# Altium schematic sheet 00_Power_Module.SchDoc
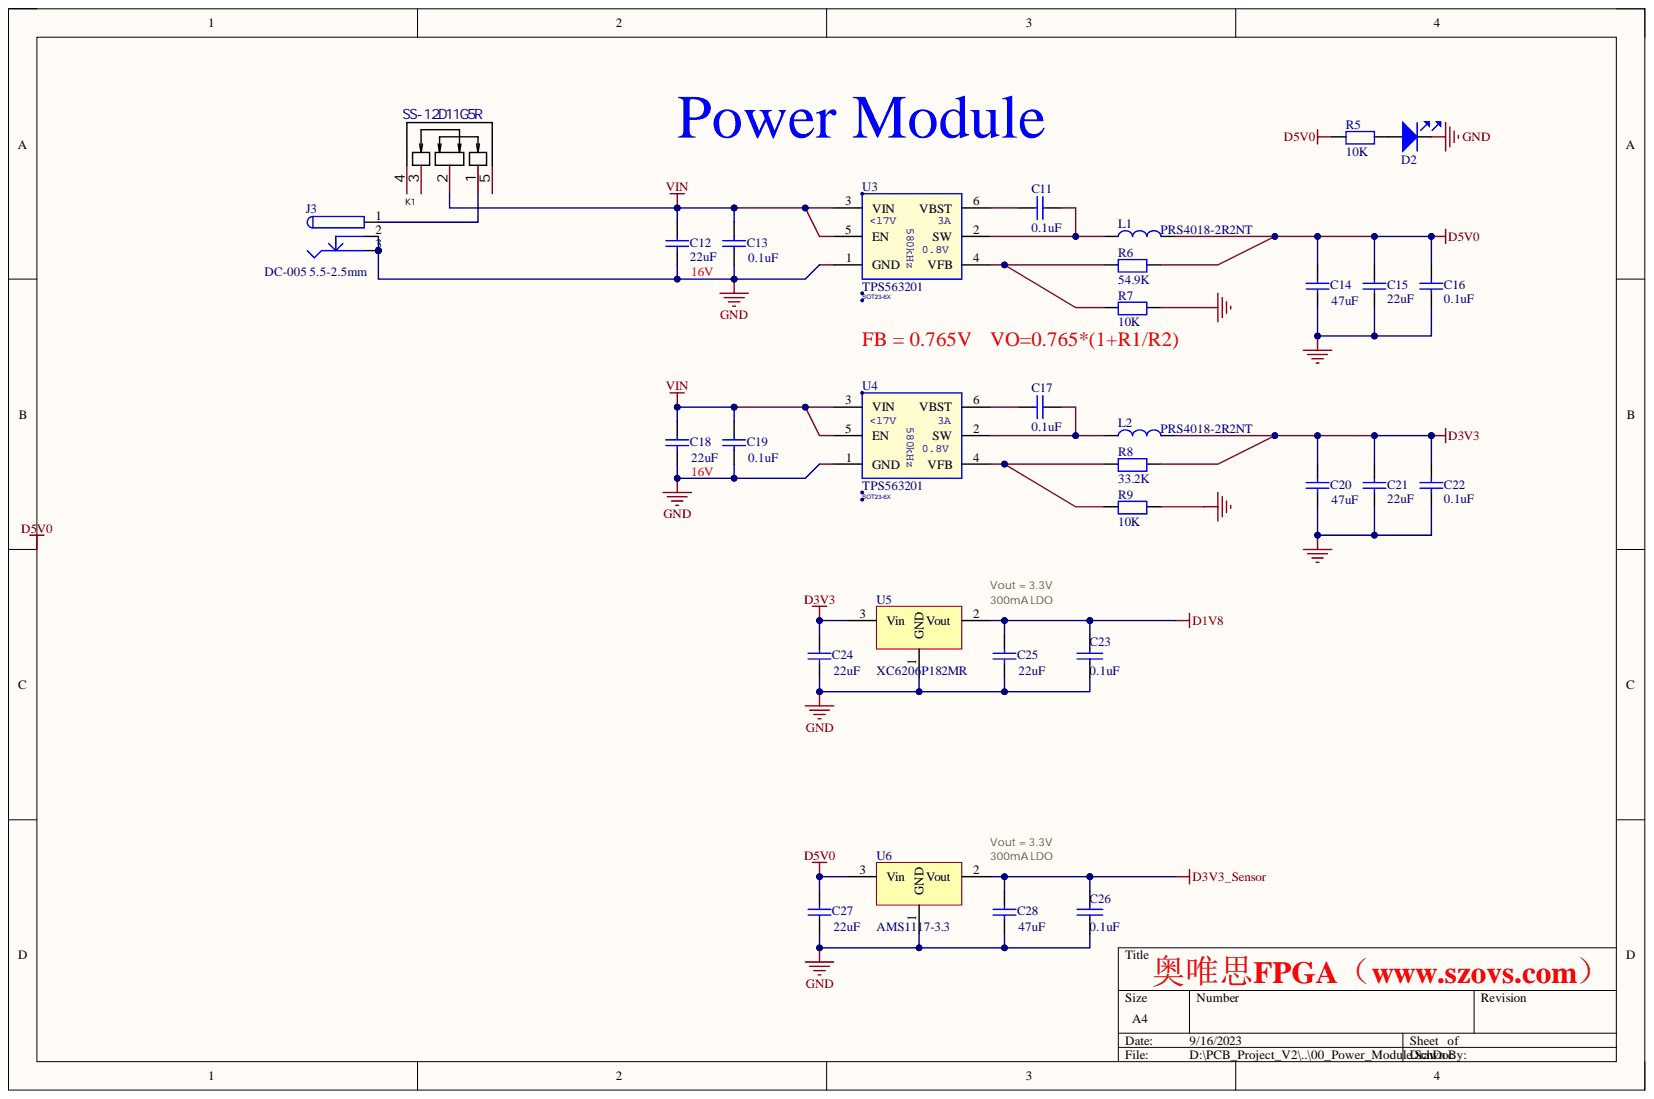
[diagram p0: 00_Power_Module.SchDoc]

## Parts
C11  0.1uF  [R0402]
C12  22uF  [R0805]
C13  0.1uF  [R0402]
C14  47uF  [R1206]
C15  22uF  [R0805]
C16  0.1uF  [R0402]
C17  0.1uF  [R0402]
C18  22uF  [R0805]
C19  0.1uF  [R0402]
C20  47uF  [R1206]
C21  22uF  [R0805]
C22  0.1uF  [R0402]
C23  0.1uF  [R0402]
C24  22uF  [R0805]
C25  22uF  [R0805]
C26  0.1uF  [R0402]
C27  22uF  [R0805]
C28  47uF  [R1206]
D2  LED红  [D0603]  (value not drawn)
J3  DC-005 5.5-2.5mm  [source]
K1  SS-12D11G5R  [SW-TH_SS-12D11G5R]
L1  PRS4018-2R2NT  [PRS4018]
L2  PRS4018-2R2NT  [PRS4018]
R5  10K  [R0402]
R6  54.9K  [R0402]
R7  10K  [R0402]
R8  33.2K  [R0402]
R9  10K  [R0402]
U3  TPS563201  PCB Footprint: SOT23-6X  [SOT23-6]
U4  TPS563201  PCB Footprint: SOT23-6X  [SOT23-6]
U5  XC6206P182MR  [SOT23-3]
U6  AMS1117-3.3  [SOT-89]

## Nets
D1V8: C23.1 C25.2 U5.2
D3V3: C20.2 C21.2 C22.2 C24.2 L2.2 R8.2 U5.3
D3V3_Sensor: C26.1 C28.2 U6.2
D5V0: C14.2 C15.2 C16.2 C27.2 L1.2 R5.1 R6.2 U6.3
GND: C12.1 C13.1 C14.1 C15.1 C16.1 C18.1 C19.1 C20.1 C21.1 C22.1 C23.2 C24.1 C25.1 C26.2 C27.1 C28.1 D2.2 J3.2 J3.3 R7.2 R9.2 U3.1(GND) U4.1(GND) U5.1 U6.1
VIN: C12.2 C13.2 C18.2 C19.2 K1.2 U3.3(VIN) U3.5(EN) U4.3(VIN) U4.5(EN)
NetC11_1: C11.1 L1.1 U3.2(SW)
NetC11_2: C11.2 U3.6(VBST)
NetC17_1: C17.1 L2.1 U4.2(SW)
NetC17_2: C17.2 U4.6(VBST)
NetD2_1: D2.1 R5.2
NetJ3_1: J3.1 K1.1
NetR6_1: R6.1 R7.1 U3.4(VFB)
NetR8_1: R8.1 R9.1 U4.4(VFB)

Not connected: K1 3 4 5

## Library data of the parts
C11-C22 C24 C25 C27 C28 = CAP: Component Kind: Standard; Library Name: Crazy_PCB.SchLib
C23 C26 = CAP: Capacitor; Component Kind: Standard; Library Name: RC522.IntLib
D2 = LED: LED 发光二极管; Component Kind: Standard; Library Name: Crazy_PCB.SchLib
J3 = SOURCE: Component Kind: Standard; LatestRevisionDate: 17-Jul-2002; LatestRevisionNote: Re-released for DXP Platform.; Library Name: Crazy_PCB.SchLib; Publisher: Altium Limited
K1 = SS-12D11G5R: Manufacturer: XKB Connectivity(中国星坤); Actuator Color: 黑色; Actuator Style: 矩形柱状; Add into BOM: yes; Circuit: 单刀双掷; Current Rating (AC): 5A; Mechanical Life: 1万次; Pin Style: PC引脚; Switch Height: 6.3mm; Switch Length: 13.5mm; Switch Width: 6.6mm; Voltage Rating (AC): 125V; Component Kind: Standard; Convert to PCB: yes; Current Rating (DC): 5A; Datasheet: https://atta.szlcsc.com/upload/public/pdf/source/20211009/84F89DEF741E27E9E55DE4039DC07CAD.pdf; Device: SS-12D11G5R; JLCPCB Part Class: Extended Part; LCSC Part Name: 柄长5mm 弯插双档 滑动开关; Manufacturer Part: SS-12D11G5R; Mounting Style: 弯插; Name: SS-12D11G5R; Operating Temperature: -40℃~+85℃; PCB3D: SW-TH_SS-12D11G5R; Supplier: LCSC; Supplier Footprint: 插件; Supplier Part: C388633; Symbol: SS-12D11G5R; Voltage Rating (DC): 125V
L1 L2 = Inductor: Component Kind: Standard; Library Name: Crazy_PCB.SchLib
R5-R9 = RES: Component Kind: Standard; Library Name: Crazy_PCB.SchLib
U3 U4 = 6_TPS563201: Component Kind: Standard
U5 U6 = AMS1117: LDO; Component Kind: Standard; Library Name: Crazy_PCB.SchLib
